# Altium schematic sheet 5-Encoders.SchDoc(5-Encoders)
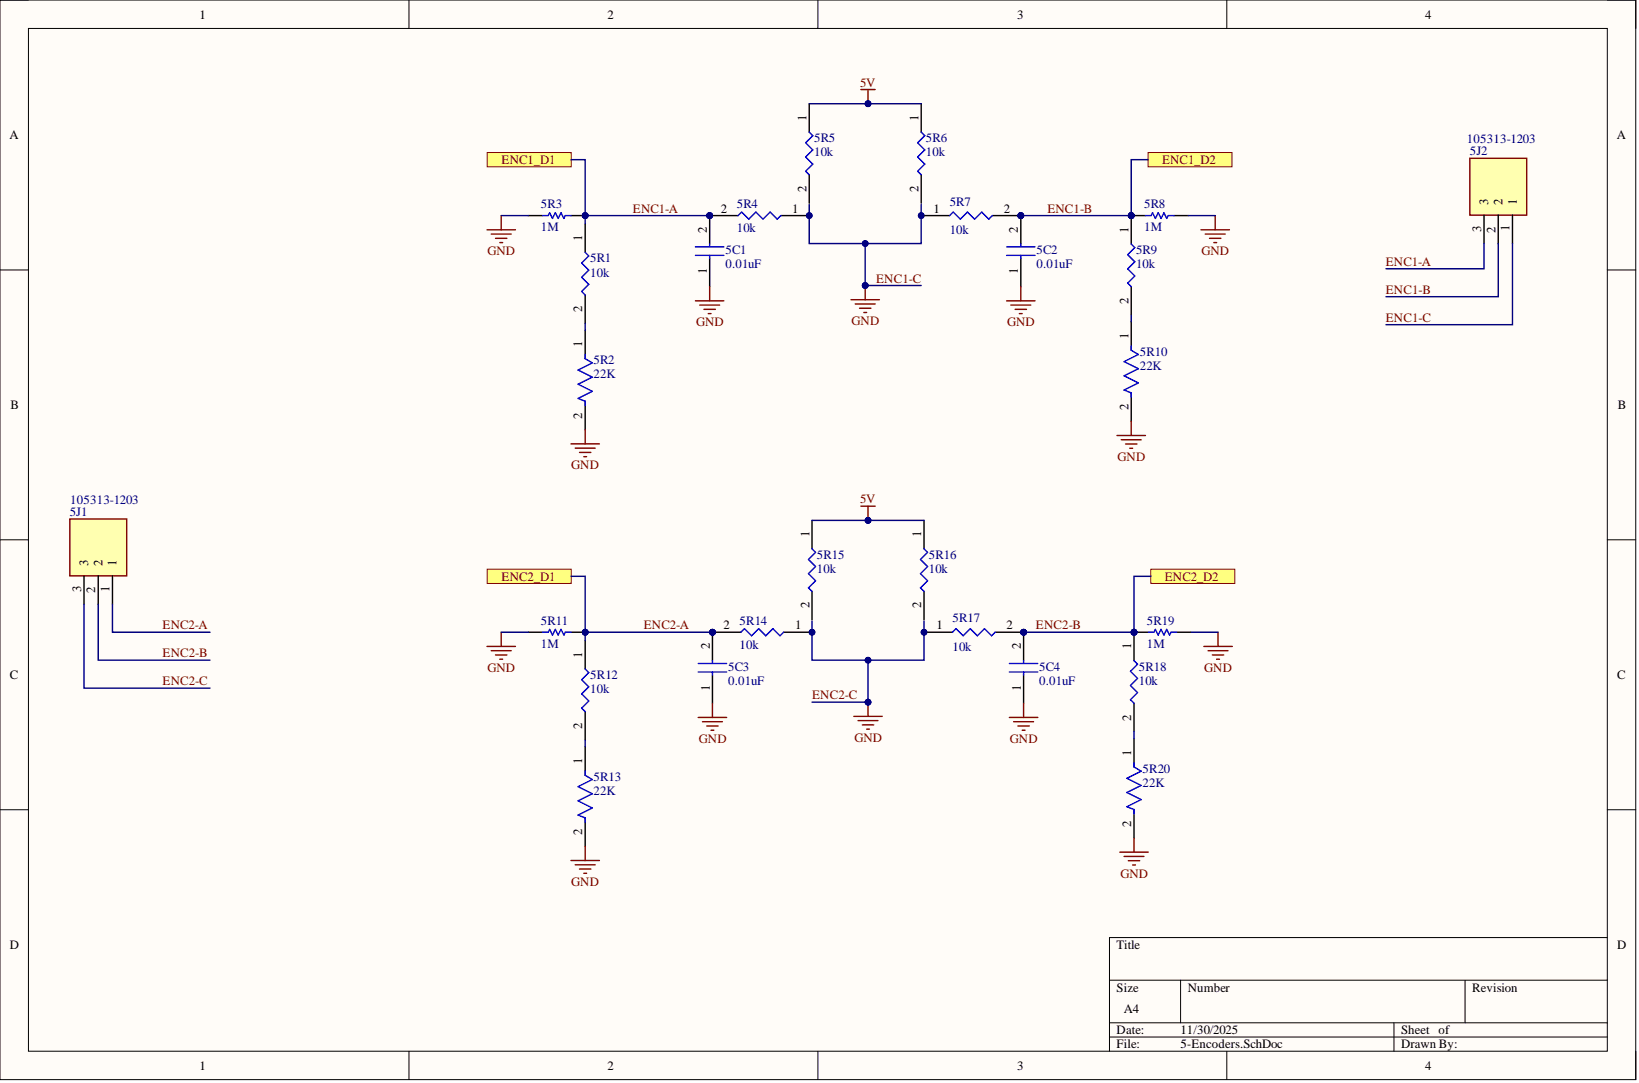
[diagram p0: 5-Encoders.SchDoc]

## Parts
5C1  0.01uF
5C2  0.01uF
5C3  0.01uF
5C4  0.01uF
5J1  105313-1203
5J2  105313-1203
5R1  10k
5R2  22K
5R3  1M
5R4  10k
5R5  10k
5R6  10k
5R7  10k
5R8  1M
5R9  10k
5R10  22K
5R11  1M
5R12  10k
5R13  22K
5R14  10k
5R15  10k
5R16  10k
5R17  10k
5R18  10k
5R19  1M
5R20  22K

## Ports
ENC1_D1, ENC1_D2, ENC2_D1, ENC2_D2

## Nets
5V: 5R5.1 5R6.1 5R15.1 5R16.1
ENC1-A: 5C1.2 5J2.3 5R1.1 5R3.2 5R4.2 ; port ENC1_D1
ENC1-B: 5C2.2 5J2.2 5R7.2 5R8.2 5R9.1 ; port ENC1_D2
ENC1-C: 5C1.1 5C2.1 5C3.1 5C4.1 5J1.3 5J2.1 5R2.2 5R3.1 5R4.1 5R7.1 5R8.1 5R10.2 5R11.1 5R13.2 5R14.1 5R17.1 5R19.1 5R20.2
ENC2-A: 5C3.2 5J1.1 5R11.2 5R12.1 5R14.2 ; port ENC2_D1
ENC2-B: 5C4.2 5J1.2 5R17.2 5R18.1 5R19.2 ; port ENC2_D2
Net5R1_2: 5R1.2 5R2.1
Net5R9_2: 5R9.2 5R10.1
Net5R12_2: 5R12.2 5R13.1
Net5R18_2: 5R18.2 5R20.1

Not connected: 5R5 2; 5R6 2; 5R15 2; 5R16 2

## Library data of the parts
5C1-5C4 = 08055C103K4Z2A: Capacitor; Manufacturer_Name: Kyocera AVX; Component Kind: Standard; Datasheet Link: http://datasheets.avx.com/X7RDielectric.pdf; Height: 0.94mm; Library Name: SamacSys.SchLib; Manufacturer_Part_Number: 08055C103K4Z2A; Mouser Part Number: 581-08055C103K4Z2A; Mouser Price/Stock: https://www.mouser.co.uk/ProductDetail/AVX/08055C103K4Z2A?qs=o86%2F%2FV5KDpwDqgSq9XIFgQ%3D%3D
5J1 5J2 = 105313-1203: Connector; Manufacturer_Name: Molex; Component Kind: Standard; Datasheet Link: https://www.molex.com/pdm_docs/sd/1053131203_sd.pdf; Height: 6.84mm; Library Name: SamacSys.SchLib; Manufacturer_Part_Number: 105313-1203; Mouser Part Number: 538-105313-1203; Mouser Price/Stock: https://www.mouser.co.uk/ProductDetail/Molex/105313-1203?qs=PqFvFmEiOr7NftsAjtORpQ%3D%3D
5R1 5R4-5R7 5R9 5R12 5R14-5R18 = ERJ-6GEYJ103V: Resistor; Manufacturer_Name: Panasonic; Arrow Part Number: ERJ-6GEYJ103V; Arrow Price/Stock: https://www.arrow.com/en/products/erj6geyj103v/panasonic?region=nac; Component Kind: Standard; Datasheet Link: https://datasheet.datasheetarchive.com/originals/distributors/DKDS40/DSANUWW0010911.pdf; Height: 0.7mm; Library Name: SamacSys.SchLib; Manufacturer_Part_Number: ERJ-6GEYJ103V; Mouser Part Number: 667-ERJ-6GEYJ103V; Mouser Price/Stock: https://www.mouser.co.uk/ProductDetail/Panasonic/ERJ-6GEYJ103V?qs=tQNqqtTOejK5rw%252BRxJVxUA%3D%3D
5R2 5R10 5R13 5R20 = CMP-02407-007310-1: RES Thick Film, 22kΩ, 5%, 0.125W, 200ppm/°C, 0805; Max Power Dissipation: 125mW; Resistance: 22kR; Voltage Rating: 150V; Case Code (Imperial): 0805; Case Code (Metric): 2012; Case/Package: 0805; Component Kind: Standard; Composition: Thick Film; Contact Plating: Tin; Depth: 1.25mm; Features: Automotive AEC-Q200; Height: 0.02inch; Lead Free: Lead Free; Length: 2mm; Library Name: Altium Content Vault; Max Operating Temperature: 155°C; Military Standard: Not; Min Operating Temperature: -55°C; Number of Terminations: 2; Package Quantity: 1; Packaging: Tape and Reel; Power Rating: 125mW; Radiation Hardening: No; Temperature Coefficient: 200ppm/°C; Termination: SMD/SMT; Tolerance: 5%; Voltage Rating (DC): 150V; Weight: 0.000194oz; Width: 0.049inch
5R3 5R8 5R11 5R19 = CMP-2001-04743-1: Manufacturer: Vishay Dale; Part Number: TNPW08051M00BEEN; Component Kind: Standard; Library Name: Altium Content Vault
